# Altium schematic sheet PowerSupplies.SchDoc(PowerSupplies)
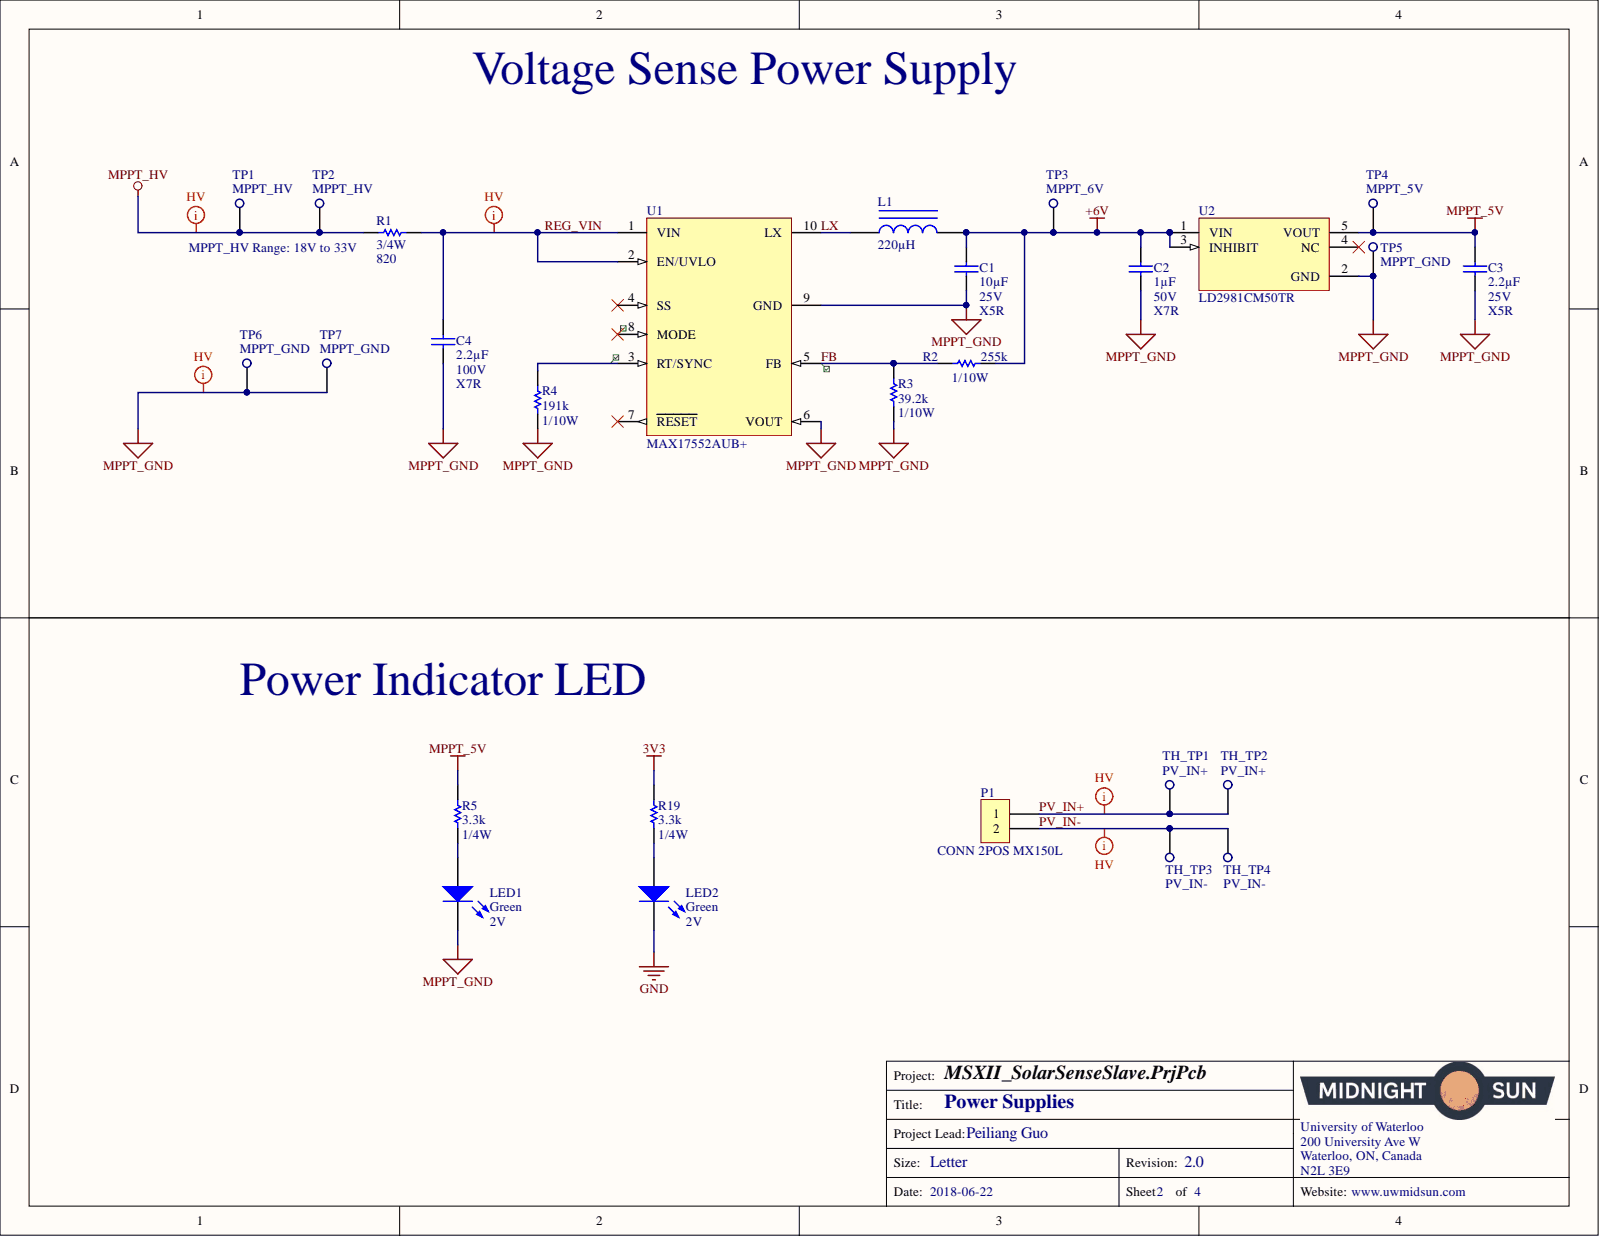
[diagram p0: PowerSupplies.SchDoc]

## Parts
C1  Voltage: 25V  Temperature Coefficient: X5R  Capacitance: 10µF  [CAP, 0603]  (hidden comment: CAP CER 10uF 25V 20% X5R 0603)
C2  Voltage: 50V  Temperature Coefficient: X7R  Capacitance: 1µF  [CAP, 0603]  (hidden comment: CAP CER 1UF 50V 10% X7R 0603)
C3  Voltage: 25V  Temperature Coefficient: X5R  Capacitance: 2.2µF  [CAP, 0603]  (hidden comment: CAP CER 2.2UF 25V 10% X5R 0603)
C4  Voltage: 100V  Temperature Coefficient: X7R  Capacitance: 2.2µF  [CAP, 1206]  (hidden comment: CAP CER 2.2UF 100V ±20% X7R 1206)
L1  Inductance: 220µH  [INDP6060X23N]  (hidden comment: IND 220uH 0.59A 20%)
LED1  Voltage - Forward (Vf) (Typ): 2V  Color: Green  [LED_0603]  (hidden comment: LED GREEN CLEAR 2V 0603)
LED2  Voltage - Forward (Vf) (Typ): 2V  Color: Green  [LED_0603]  (hidden comment: LED GREEN CLEAR 2V 0603)
P1  CONN 2POS MX150L  [CONN, 2POS MX150L]
R1  Power: 3/4W  [RES 2010]  (hidden comment: RES 820 OHM 5% 3/4W 2010)
R2  Resistance (Ohms): 255k  Power (Watts): 1/10W  [RES, 0603]  (hidden comment: RES 255K OHM 1% 1/10W 0603)
R3  Resistance: 39.2k  Power: 1/10W  [RES, 0603]  (hidden comment: RES 39.2K OHM 1% 1/10W 0603)
R4  Resistance: 191k  Power: 1/10W  [RES, 0603]  (hidden comment: RES 191K OHM 1% 1/10W 0603)
R5  Resistance: 3.3k  Power: 1/4W  [RES, 0603]  (hidden comment: RES 3.3K OHM 1% 1/4W 0603)
R19  Resistance: 3.3k  Power: 1/4W  [RES, 0603]  (hidden comment: RES 3.3K OHM 1% 1/4W 0603)
TH_TP1  PV_IN+  [Thru Test Point]
TH_TP2  PV_IN+  [Thru Test Point]
TH_TP3  PV_IN-  [Thru Test Point]
TH_TP4  PV_IN-  [Thru Test Point]
TP1  MPPT_HV  [Thru Test Point]
TP2  MPPT_HV  [Thru Test Point]
TP3  MPPT_6V  [Thru Test Point]
TP4  MPPT_5V  [Thru Test Point]
TP5  MPPT_GND  [Thru Test Point]
TP6  MPPT_GND  [Thru Test Point]
TP7  MPPT_GND  [Thru Test Point]
U1  Manufacturer Part Number 1: MAX17552AUB+  [TSSOP50P490X110-10N]  (hidden comment: IC REG BUCK ADJ 0.1A 10UMAX)
U2  Manufacturer Part Number 1: LD2981CM50TR  [SOT95P280X145-5N]  (hidden comment: IC REG LDO 5V 0.1A SOT23-5)

## Nets
3V3: R19.1
+6V: C1.1 C2.1 L1.2 R2.2 TP3.TH_TP U2.1(VIN) U2.3(INHIBIT)
GND: LED2.2
MPPT_5V: C3.1 R5.1 TP4.TH_TP U2.5(VOUT)
MPPT_GND: C1.2 C2.2 C3.2 C4.2 LED1.2 R3.2 R4.2 TP5.TH_TP TP6.TH_TP TP7.TH_TP U1.6(VOUT) U1.9(GND) U2.2(GND)
MPPT_HV: R1.1 TP1.TH_TP TP2.TH_TP
FB: R2.1 R3.1 U1.5(FB)
LX: L1.1 U1.10(LX)
PV_IN+: P1.1 TH_TP1.TH_TP TH_TP2.TH_TP
PV_IN-: P1.2 TH_TP3.TH_TP TH_TP4.TH_TP
REG_VIN: C4.1 R1.2 U1.1(VIN) U1.2(EN/UVLO)
NetLED1_1: LED1.1 R5.2
NetLED2_1: LED2.1 R19.2
NetR4_1: R4.1 U1.3(RT/SYNC)

Not connected: U1 4(SS) 7(RESET) 8(MODE); U2 4(NC)

## Library data of the parts
C1 = CAP CER 10uF 25V 20% X5R 0603: 10µF ±20% 25V Ceramic Capacitor X5R 0603 (1608 Metric); Manufacturer Part Number 1: GRM188R61E106MA73D; Manufacturer 1: Murata Electronics North America; Tolerance: ±20%; Thickness (Max): 0.031" (0.80mm); Supplier Part Number 1: 490-7202-1-ND; Supplier 1: Digi-Key; Size / Dimension: 0.063" L x 0.031" W (1.60mm x 0.80mm); Ratings: -; Part Status: Active; Packaging: Cut Tape (CT); Package / Case: 0603 (1608 Metric); Operating Temperature: -55°C ~ 85°C; Mounting Type: Surface Mount, MLCC; Lead Style: -; Lead Spacing: -; Height - Seated (Max): -; ComponentLink1URL: http://www.murata.com/~/media/webrenewal/support/library/catalog/products/capacitor/mlcc/c02e.ashx; ComponentLink1Description: http://www.murata.com/~/media/webrenewal/support/library/catalog/products/capacitor/mlcc/c02e.ashx; Component Kind: Standard; Library Name: Schematic Diagrams.SchLib
C2 = CAP CER 1UF 50V 10% X7R 0603: 1µF ±10% 50V Ceramic Capacitor X7R 0603 (1608 Metric); Manufacturer Part Number 1: UMK107AB7105KA-T; Manufacturer 1: Taiyo Yuden; Tolerance: ±10%; Thickness (Max): 0.037" (0.95mm); Supplier Part Number 1: 587-3247-1-ND; Supplier 1: Digi-Key; Size / Dimension: 0.063" L x 0.031" W (1.60mm x 0.80mm); Ratings: -; Part Status: Active; Packaging: Cut Tape (CT); Package / Case: 0603 (1608 Metric); Operating Temperature: -55°C ~ 125°C; Mounting Type: Surface Mount, MLCC; Lead Style: -; Lead Spacing: -; Height - Seated (Max): -; ComponentLink1URL: http://www.yuden.co.jp/productdata/catalog/en/mlcc_all_e.pdf; ComponentLink1Description: http://www.yuden.co.jp/productdata/catalog/en/mlcc_all_e.pdf; Component Kind: Standard; Library Name: Schematic Diagrams.SchLib
C3 = CAP CER 2.2UF 25V 10% X5R 0603: 2.2µF ±10% 25V Ceramic Capacitor X5R 0603 (1608 Metric); Manufacturer Part Number 1: GRM188R61E225KA12D; Manufacturer 1: Murata Electronics North America; Tolerance: ±10%; Thickness (Max): 0.035" (0.90mm); Supplier Part Number 1: 490-10731-1-ND; Supplier 1: Digi-Key; Size / Dimension: 0.063" L x 0.031" W (1.60mm x 0.80mm); Ratings: -; Part Status: Active; Packaging: Cut Tape (CT); Package / Case: 0603 (1608 Metric); Operating Temperature: -55°C ~ 85°C; Mounting Type: Surface Mount, MLCC; Lead Style: -; Lead Spacing: -; Height - Seated (Max): -; ComponentLink1URL: http://www.murata.com/~/media/webrenewal/support/library/catalog/products/capacitor/mlcc/c02e.ashx; ComponentLink1Description: http://www.murata.com/~/media/webrenewal/support/library/catalog/products/capacitor/mlcc/c02e.ashx; Component Kind: Standard; Library Name: Schematic Diagrams.SchLib
C4 = CAP CER 2.2UF 100V ±20% X7R 1206: 2.2µF ±20% 100V Ceramic Capacitor X7R 1206 (3216 Metric); Manufacturer Part Number 1: GRM31CR72A225MA73L; Manufacturer 1: Murata Electronics North America; Tolerance: ±20%; Thickness (Max): 0.071" (1.80mm); Supplier Part Number 1: 490-12773-1-ND; Supplier 1: Digi-Key; Size / Dimension: 0.126" L x 0.063" W (3.20mm x 1.60mm); Ratings: -; Part Status: Active; Packaging: Cut Tape (CT); Package / Case: 1206 (3216 Metric); Operating Temperature: -55°C ~ 125°C; Mounting Type: Surface Mount, MLCC; Lead Style: -; Lead Spacing: -; Height - Seated (Max): -; ComponentLink1URL: http://www.murata.com/~/media/webrenewal/support/library/catalog/products/capacitor/mlcc/c02e.ashx; ComponentLink1Description: http://www.murata.com/~/media/webrenewal/support/library/catalog/products/capacitor/mlcc/c02e.ashx; Component Kind: Standard; Library Name: Schematic Diagrams.SchLib
L1 = IND 220uH 0.59A 20%: 220µH Shielded Inductor 590mA 834 mOhm Nonstandard; Manufacturer Part Number 1: TYS6045221M-10; Manufacturer 1: Laird-Signal Integrity Products; Frequency - Self Resonant: 3.5MHz; Current - Saturation: 700mA; Type: -; Tolerance: ±20%; Supplier Part Number 1: 240-2742-1-ND; Supplier Device Package: -; Supplier 1: Digi-Key; Size / Dimension: 0.236" L x 0.236" W (6.00mm x 6.00mm); Shielding: Shielded; Ratings: AEC-Q200; Q @ Freq: -; Part Status: Active; Packaging: Cut Tape (CT); Package / Case: Nonstandard; Operating Temperature: -40°C ~ 125°C; Mounting Type: Surface Mount; Material - Core: -; Height - Seated (Max): 0.185" (4.70mm); DC Resistance (DCR): 834 mOhm; Current Rating: 590mA; ComponentLink1URL: http://assets.lairdtech.com/home/brandworld/files/TYS6045221M-10.pdf; ComponentLink1Description: http://assets.lairdtech.com/home/brandworld/files/TYS6045221M-10.pdf; Component Kind: Standard; Library Name: Schematic Diagrams.SchLib
LED1 LED2 = LED GREEN CLEAR 2V 0603: Green 572nm LED Indication - Discrete 2V 0603 (1608 Metric); Manufacturer Part Number: 150060VS75000; Manufacturer: Wurth Electronics Inc.; Wavelength - Dominant: 570nm; Viewing Angle: 140°; Millicandela Rating: 40mcd; Lens Style/Size: Rectangle with Flat Top, 1.10mm x 0.70mm; Lens Color: Colorless; Current - Test: 20mA; Wavelength - Peak: 572nm; Supplier Part Number 1: 732-4980-1-ND; Supplier Device Package: 0603; Supplier 1: Digi-Key; Stock 1: 9408; Size / Dimension: 1.60mm L x 0.80mm W; RoHS: RoHS Compliant; Pricing 1: 1=0.21, 100=0.1617, 500=0.13964 (CAD); Part Status: Active; Packaging: Cut Tape (CT); Package / Case: 0603 (1608 Metric); Mounting Type: Surface Mount; Lens Transparency: Clear; Height (Max): 0.80mm; Features: -; ComponentLink1URL: http://katalog.we-online.de/led/datasheet/150060VS75000.pdf; ComponentLink1Description: http://katalog.we-online.de/led/datasheet/150060VS75000.pdf; Component Kind: Standard; Category: Optoelectronics; Library Name: Schematic Diagrams.SchLib
P1 = CONN 2POS MX150L: Connector Header Panel Mount, Through Hole, Right Angle 2 position 0.230" (5.84mm); Manufacturer Part Number: 0194270109; Manufacturer: Molex, LLC; Style: Board to Cable/Wire; Shrouding: Shrouded - 4 Wall; Number of Positions Loaded: All; Number of Positions: 2; Material Flammability Rating: UL94 V-0; Contact Length - Post: 0.134" (3.40mm); Voltage Rating: -; Termination: Solder; Supplier Part Number 1: 0194270109-ND; Supplier 1: Digi-Key; Stock 1: 0; Row Spacing - Mating: -; RoHS: RoHS Compliant; Pricing 1: 1040=3.59614 (CAD); Pitch - Mating: 0.230" (5.84mm); Part Status: Active; Packaging: Tray; Overall Contact Length: -; Operating Temperature: -; Number of Rows: 1; Mounting Type: Panel Mount, Through Hole, Right Angle; Mated Stacking Heights: -; Insulation Material: Polybutylene Terephthalate (PBT), Glass Filled; Insulation Height: 1.147" (29.13mm); Insulation Color: Black; Ingress Protection: -; Features: Board Guide; Fastening Type: Locking Ramp; Current Rating: -; Contact Type: Male Pin; Contact Shape: Square; Contact Material: Copper Alloy; Contact Length - Mating: -; Contact Finish Thickness - Post: 100.0µin (2.54µm); Contact Finish Thickness - Mating: 30.0µin (0.76µm); Contact Finish - Post: Tin; Contact Finish - Mating: Gold; Connector Type: Header; ComponentLink1URL: https://www.molex.com/pdm_docs/sd/194270109_sd.pdf; ComponentLink1Description: https://www.molex.com/pdm_docs/sd/194270109_sd.pdf; Component Kind: Standard; Category: Connectors, Interconnects; Applications: Automotive, General Purpose, Industrial; Library Name: Schematic Diagrams.SchLib
R1 = RES 820 OHM 5% 3/4W 2010: 820 Ohms ±5% 0.75W, 3/4W Chip Resistor 2010 (5025 Metric) Automotive AEC-Q200 Thick Film; Manufacturer Part Number: RMCF2010JT820R; Manufacturer: Stackpole Electronics Inc.; Temperature Coefficient: ±200ppm/°C; Resistance: 820; Tolerance: ±5%; Supplier Part Number 1: RMCF2010JT820RCT-ND; Supplier Device Package: 2010; Supplier 1: Digi-Key; Stock 1: 9416; Size / Dimension: 0.197" L x 0.098" W (5.00mm x 2.50mm); RoHS: RoHS Compliant; Pricing 1: 1=0.35, 10=0.291, 100=0.1135, 1000=0.04752 (CAD); Power (Watts): 0.75W, 3/4W; Part Status: Active; Packaging: Cut Tape (CT); Package / Case: 2010 (5025 Metric); Operating Temperature: -55°C ~ 155°C; Height - Seated (Max): 0.028" (0.70mm); Features: Automotive AEC-Q200; Failure Rate: -; Composition: Thick Film; ComponentLink1URL: https://www.seielect.com/Catalog/SEI-RMCF_RMCP.pdf; ComponentLink1Description: https://www.seielect.com/Catalog/SEI-RMCF_RMCP.pdf; Component Kind: Standard; Category: Resistors; Library Name: Schematic Diagrams.SchLib
R2 = RES 255K OHM 1% 1/10W 0603: 255k Ohm ±1% 0.1W, 1/10W Chip Resistor 0603 (1608 Metric) Moisture Resistant Thick Film; Manufacturer Part Number 1: RC0603FR-07255KL; Manufacturer 1: Yageo; Temperature Coefficient: ±100ppm/°C; Description 1: RES SMD 255K OHM 1% 1/10W 0603; Tolerance: ±1%; Supplier Part Number 1: 311-255KHRCT-ND; Supplier Device Package: 0603; Supplier 1: Digi-Key; Stock 1: 106564; Size / Dimension: 0.063" L x 0.031" W (1.60mm x 0.80mm); RoHS: RoHS Compliant; Pricing 1: 1=0.16, 10=0.022, 100=0.0089, 1000=0.00402, 2500=0.00349 (CAD); Part Status: Active; Packaging: Cut Tape (CT); Package / Case: 0603 (1608 Metric); Operating Temperature: -55°C ~ 155°C; Height - Seated (Max): 0.022" (0.55mm); Features: Moisture Resistant; Failure Rate: -; Composition: Thick Film; ComponentLink1URL: http://www.yageo.com/documents/recent/PYu-RC_Group_51_RoHS_L_7.pdf; ComponentLink1Description: http://www.yageo.com/documents/recent/PYu-RC_Group_51_RoHS_L_7.pdf; Component Kind: Standard; Category: Resistors; Library Name: Schematic Diagrams.SchLib
R3 = RES 39.2K OHM 1% 1/10W 0603: 39.2k Ohm ±1% 0.1W, 1/10W Chip Resistor 0603 (1608 Metric) Moisture Resistant Thick Film; Manufacturer Part Number 1: RC0603FR-0739K2L; Manufacturer 1: Yageo; Temperature Coefficient: ±100ppm/°C; Tolerance: ±1%; Supplier Part Number 1: 311-39.2KHRCT-ND; Supplier Device Package: 0603; Supplier 1: Digi-Key; Size / Dimension: 0.063" L x 0.031" W (1.60mm x 0.80mm); Part Status: Active; Packaging: Cut Tape (CT); Package / Case: 0603 (1608 Metric); Operating Temperature: -55°C ~ 155°C; Height - Seated (Max): 0.022" (0.55mm); Failure Rate: -; Composition: Thick Film; ComponentLink1URL: http://www.yageo.com/documents/recent/PYu-RC_Group_51_RoHS_L_7.pdf; ComponentLink1Description: http://www.yageo.com/documents/recent/PYu-RC_Group_51_RoHS_L_7.pdf; Component Kind: Standard; Library Name: Schematic Diagrams.SchLib
R4 = RES 191K OHM 1% 1/10W 0603: 191k Ohm ±1% 0.1W, 1/10W Chip Resistor 0603 (1608 Metric) Moisture Resistant Thick Film; Manufacturer Part Number 1: RC0603FR-07191KL; Manufacturer 1: Yageo; Temperature Coefficient: ±100ppm/°C; Tolerance: ±1%; Supplier Part Number 1: 311-191KHRCT-ND; Supplier Device Package: 0603; Supplier 1: Digi-Key; Size / Dimension: 0.063" L x 0.031" W (1.60mm x 0.80mm); Part Status: Active; Packaging: Cut Tape (CT); Package / Case: 0603 (1608 Metric); Operating Temperature: -55°C ~ 155°C; Height - Seated (Max): 0.022" (0.55mm); Failure Rate: -; Composition: Thick Film; ComponentLink1URL: http://www.yageo.com/documents/recent/PYu-RC_Group_51_RoHS_L_7.pdf; ComponentLink1Description: http://www.yageo.com/documents/recent/PYu-RC_Group_51_RoHS_L_7.pdf; Component Kind: Standard; Library Name: Schematic Diagrams.SchLib
R5 R19 = RES 3.3K OHM 1% 1/4W 0603: 3.3k Ohm ±1% 0.25W, 1/4W Chip Resistor 0603 (1608 Metric) Automotive AEC-Q200, Pulse Withstanding Thick Film; Manufacturer Part Number 1: ERJ-PA3F3301V; Manufacturer 1: Panasonic Electronic Components; Temperature Coefficient: ±100ppm/°C; Tolerance: ±1%; Supplier Part Number 1: P3.3KBYCT-ND; Supplier Device Package: 0603; Supplier 1: Digi-Key; Size / Dimension: 0.063" L x 0.031" W (1.60mm x 0.80mm); Part Status: Active; Packaging: Cut Tape (CT); Package / Case: 0603 (1608 Metric); Operating Temperature: -55°C ~ 155°C; Height - Seated (Max): 0.022" (0.55mm); Failure Rate: -; Composition: Thick Film; ComponentLink1URL: https://industrial.panasonic.com/cdbs/www-data/pdf/RDO0000/AOA0000C291.pdf; ComponentLink1Description: https://industrial.panasonic.com/cdbs/www-data/pdf/RDO0000/AOA0000C291.pdf; Component Kind: Standard; Library Name: Schematic Diagrams.SchLib
TH_TP1-TH_TP4 TP1-TP7 = Thru Test Point: Component Kind: Standard; Library Name: Schematic Diagrams.SchLib
U1 = IC REG BUCK ADJ 0.1A 10UMAX: Buck Switching Regulator IC Positive Adjustable 0.8V 1 Output 100mA 10-TFSOP, 10-MSOP (0.118", 3.00mm Width); Manufacturer 1: Maxim Integrated; Voltage - Output (Max): 54V; Voltage - Input (Min): 4V; Voltage - Input (Max): 60V; Synchronous Rectifier: Yes; Number of Outputs: 1; Function: Step-Down; Frequency - Switching: 100kHz ~ 2.2MHz; Voltage - Output (Min/Fixed): 0.8V; Topology: Buck; Supplier Part Number 1: MAX17552AUB+-ND; Supplier Device Package: 10-uMAX; Supplier 1: Digi-Key; Part Status: Active; Packaging: Tube; Package / Case: 10-TFSOP, 10-MSOP (0.118", 3.00mm Width); Output Type: Adjustable; Output Configuration: Positive; Operating Temperature: -40°C ~ 125°C (TJ); Mounting Type: Surface Mount; Current - Output: 100mA; ComponentLink1URL: http://datasheets.maximintegrated.com/en/ds/MAX17552.pdf; ComponentLink1Description: http://datasheets.maximintegrated.com/en/ds/MAX17552.pdf; Component Kind: Standard; Library Name: Schematic Diagrams.SchLib
U2 = IC REG LDO 5V 0.1A SOT23-5: Linear Voltage Regulator IC Positive Fixed Output 5V 100mA SOT-23-5; Manufacturer 1: STMicroelectronics; Voltage - Input: Up to 16V; Voltage - Dropout (Typical): 0.18V @ 100mA; Voltage - Output: 5V; Supplier Part Number 1: 497-7787-1-ND; Supplier Device Package: SOT-23-5; Supplier 1: Digi-Key; Regulator Topology: Positive Fixed; Part Status: Active; Packaging: Cut Tape (CT); Package / Case: SC-74A, SOT-753; Operating Temperature: -40°C ~ 125°C; Mounting Type: Surface Mount; Current - Output: 100mA; Current - Limit (Min): -; ComponentLink1URL: http://www.st.com/content/ccc/resource/technical/document/datasheet/group1/b7/b5/a1/83/9c/bd/4a/90/CD00001635/files/CD00001635.pdf/jcr:content/translations/en.CD00001635.pdf; ComponentLink1Description: http://www.st.com/content/ccc/resource/technical/document/datasheet/group1/b7/b5/a1/83/9c/bd/4a/90/CD00001635/files/CD00001635.pdf/jcr:content/translations/en.CD00001635.pdf; Component Kind: Standard; Library Name: Schematic Diagrams.SchLib
